# Altium schematic sheet Connectors_and_Regs.SchDoc(Connectors_and_Regs)
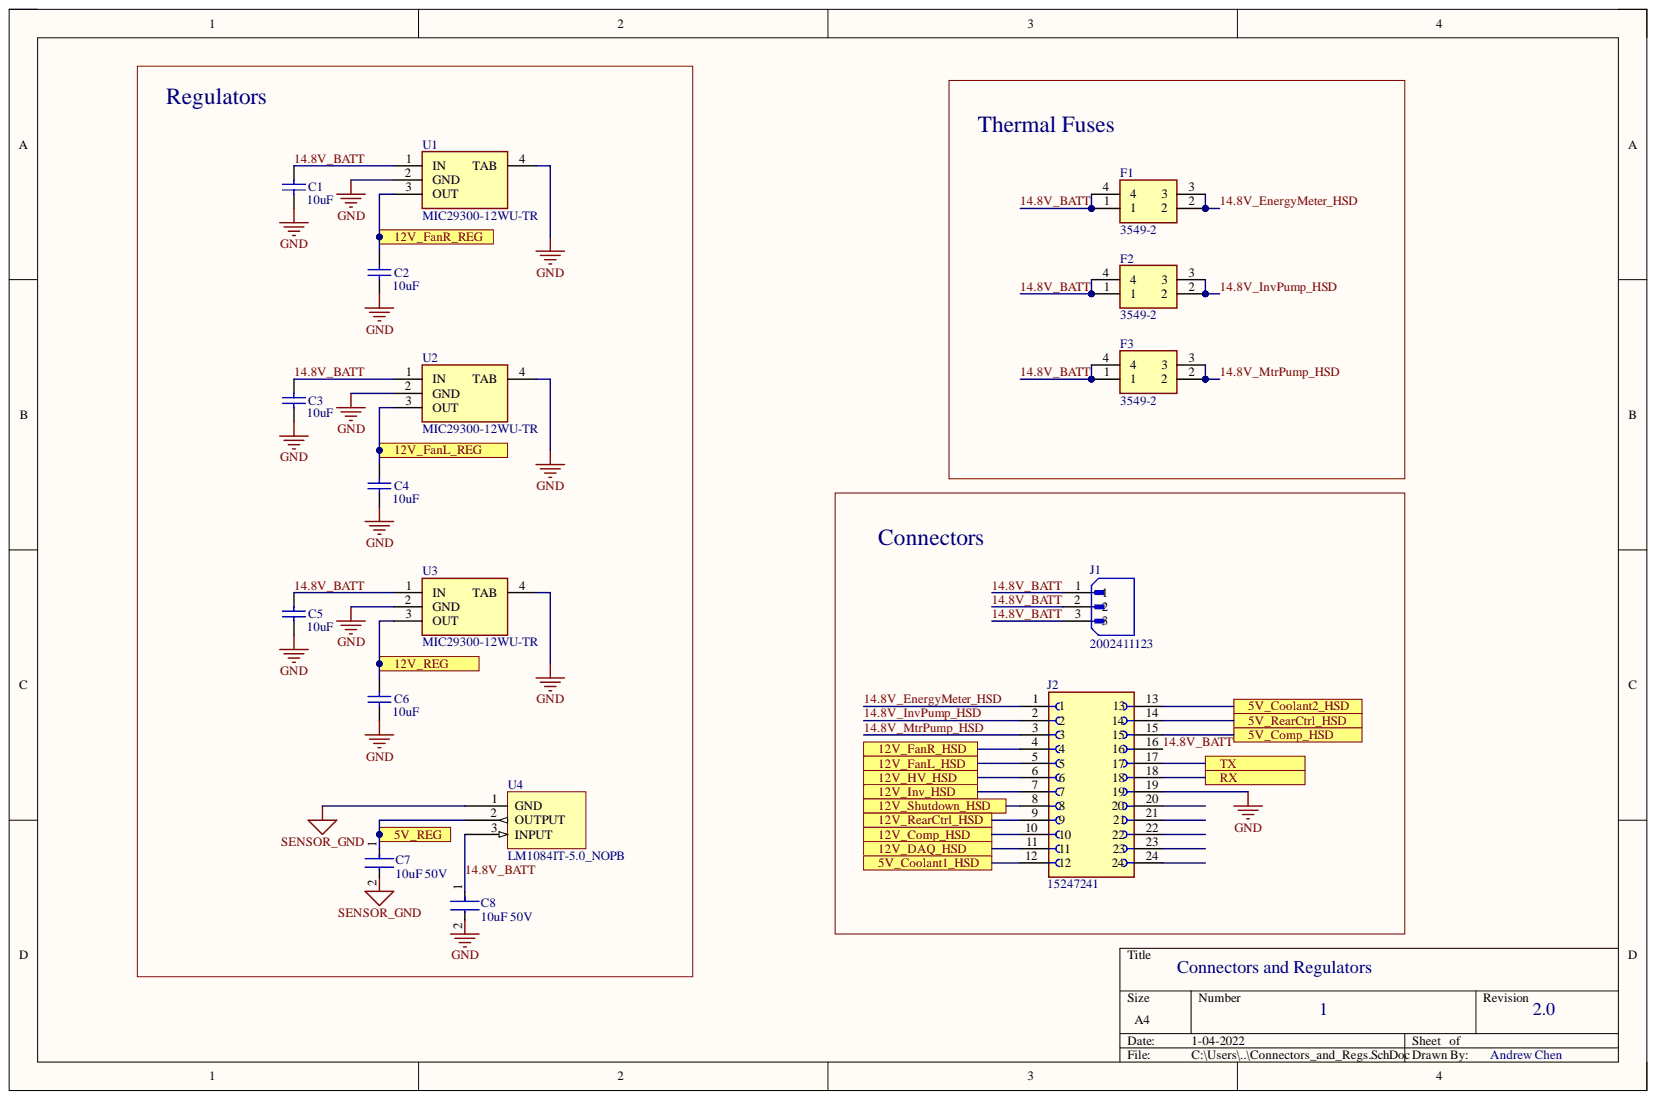
[diagram p0: Connectors_and_Regs.SchDoc]

## Parts
C1  10uF  [C1206]
C2  10uF  [C1206]
C3  10uF  [C1206]
C4  10uF  [C1206]
C5  10uF  [C1206]
C6  10uF  [C1206]
C7  10uF 50V  [FP-CL31-IPC_A]
C8  10uF 50V  [FP-CL31-IPC_A]
F1  3549-2  [35492]
F2  3549-2  [35492]
F3  3549-2  [35492]
J1  2002411123  [MOLEX_2002411123]
J2  15247241  [MOLEX_15247241]
U1  MIC29300-12WU-TR  [MIC2930012WUTR]
U2  MIC29300-12WU-TR  [MIC2930012WUTR]
U3  MIC29300-12WU-TR  [MIC2930012WUTR]
U4  LM1084IT-5.0_NOPB  [LM1084IT-5.0_NOPB]

## Ports
5V_Comp_HSD, 5V_Coolant1_HSD, 5V_Coolant2_HSD, 5V_RearCtrl_HSD, 5V_REG, 12V_Comp_HSD, 12V_DAQ_HSD, 12V_FanL_HSD, 12V_FanL_REG, 12V_FanR_HSD, 12V_FanR_REG, 12V_HV_HSD, 12V_Inv_HSD, 12V_RearCtrl_HSD, 12V_REG, 12V_Shutdown_HSD, RX, TX

## Nets
GND: C1.2 C2.2 C3.2 C4.2 C5.2 C6.2 C8.2 J2.19 U1.2(GND) U1.4(TAB) U2.2(GND) U2.4(TAB) U3.2(GND) U3.4(TAB)
SENSOR_GND: C7.2 U4.1
5V_Comp_HSD: J2.15 ; port 5V_Comp_HSD
5V_Coolant1_HSD: J2.12 ; port 5V_Coolant1_HSD
5V_Coolant2_HSD: J2.13 ; port 5V_Coolant2_HSD
5V_RearCtrl_HSD: J2.14 ; port 5V_RearCtrl_HSD
5V_REG: C7.1 U4.2 ; port 5V_REG
12V_Comp_HSD: J2.10 ; port 12V_Comp_HSD
12V_DAQ_HSD: J2.11 ; port 12V_DAQ_HSD
12V_FanL_HSD: J2.5 ; port 12V_FanL_HSD
12V_FanL_REG: C4.1 U2.3(OUT) ; port 12V_FanL_REG
12V_FanR_HSD: J2.4 ; port 12V_FanR_HSD
12V_FanR_REG: C2.1 U1.3(OUT) ; port 12V_FanR_REG
12V_HV_HSD: J2.6 ; port 12V_HV_HSD
12V_Inv_HSD: J2.7 ; port 12V_Inv_HSD
12V_RearCtrl_HSD: J2.9 ; port 12V_RearCtrl_HSD
12V_REG: C6.1 U3.3(OUT) ; port 12V_REG
12V_Shutdown_HSD: J2.8 ; port 12V_Shutdown_HSD
14.8V_BATT: C1.1 C3.1 C5.1 C8.1 F1.1 F1.4 F2.1 F2.4 F3.1 F3.4 J1.1 J1.2 J1.3 J2.16 U1.1(IN) U2.1(IN) U3.1(IN) U4.3
14.8V_EnergyMeter_HSD: F1.2 F1.3 J2.1
14.8V_InvPump_HSD: F2.2 F2.3 J2.2
14.8V_MtrPump_HSD: F3.2 F3.3 J2.3
RX: J2.18 ; port RX
TX: J2.17 ; port TX

Not connected: J2 20 21 22 23 24

## Library data of the parts
C1-C6 = Cap Semi: Capacitor (Semiconductor SIM Model); Value: 100nF; Component Kind: Standard; LatestRevisionDate: 29-May-2009; LatestRevisionNote: IPC-7351 Footprint Added.; Library Name: Miscellaneous Devices.IntLib; PackageDescription: Chip Capacitor; PackageReference: C1206; Published: 8-Jun-2000; Signal Integrity: Cap Semi; Simulation: CAP; Publisher: Altium Limited
C7 C8 = CMP-13271-002699-1: Cap Ceramic 4.7uF 16V X7R ±10% SMD 1206 +125°C Embossed T/R; Capacitance: 4.7uF; Case Code (Imperial): 1206; Case Code (Metric): 3216; Case/Package: 1206; Component Kind: Standard; Depth: 1.6mm; Dielectric: X7R; Height: 1.6mm; Length: 0.126inch; Library Name: Altium Content Vault; Max Operating Temperature: 125°C; Min Operating Temperature: -55°C; Mount: Surface Mount; Package Quantity: 1; Packaging: Tape and Reel; Radiation Hardening: No; Thickness: 0.071inch; Tolerance: 10%; Voltage Rating: 16V; Width: 0.063inch
F1-F3 = 3549-2: Fuse; Manufacturer_Name: Keystone Electronics; Component Kind: Standard; Datasheet Link: https://componentsearchengine.com/Datasheets/1/3549-2.pdf; Height: 5.66mm; Manufacturer_Part_Number: 3549-2; Mouser Part Number: 534-3549-2; Mouser Price/Stock: https://www.mouser.co.uk/ProductDetail/Keystone-Electronics/3549-2?qs=fP5bVVCrK%2Fei3gwwxH1JKw%3D%3D
J2 = 15247241: Mini-Fit BMI Receptacle Header, 4.20mm Pitch, Dual Row, Vertical, with Snap-In Plastic Pegs, 0.80Âµm Gold (Au), UL 94V-0, 24 Circuits; MF: Molex; Availability: In Stock; Check_prices: https://www.snapeda.com/parts/15247241/Molex/view-part/?ref=eda; Component Kind: Standard; Library Name: 15247241.SchLib; MP: 15247241; Package: None; Price: None; Purchase-URL: https://pricing.snapeda.com/search/part/15247241/?ref=eda; SnapEDA_Link: https://www.snapeda.com/parts/15247241/Molex/view-part/?ref=snap
U1-U3 = MIC29300-12WU-TR: Integrated Circuit; Manufacturer_Name: Microchip; Arrow Part Number: MIC29300-12WU-TR; Arrow Price/Stock: https://www.arrow.com/en/products/mic29300-12wu-tr/microchip-technology?region=nac; Component Kind: Standard; Datasheet Link: http://www.microchip.com/mymicrochip/filehandler.aspx?ddocname=en580805; Height: 4.597mm; Library Name: MIC29300-12WU.SchLib; Manufacturer_Part_Number: MIC29300-12WU-TR; Mouser Part Number: 998-MIC29300-12WUTR; Mouser Price/Stock: https://www.mouser.co.uk/ProductDetail/Microchip-Technology-Micrel/MIC29300-12WU-TR?qs=U6T8BxXiZAUm3rpKH4l03A%3D%3D
J1 = 2002411123: Availability: Unavailable; Component Kind: Standard; Library Name: 2002411123.SchLib; MP: 2002411123; Package: None; Price: None
U4 = LM1084IT-5.0_NOPB: Component Kind: Standard; Library Name: Schlib1.SchLib
